# Altium schematic sheet Radioino.SchDoc(Radioino)
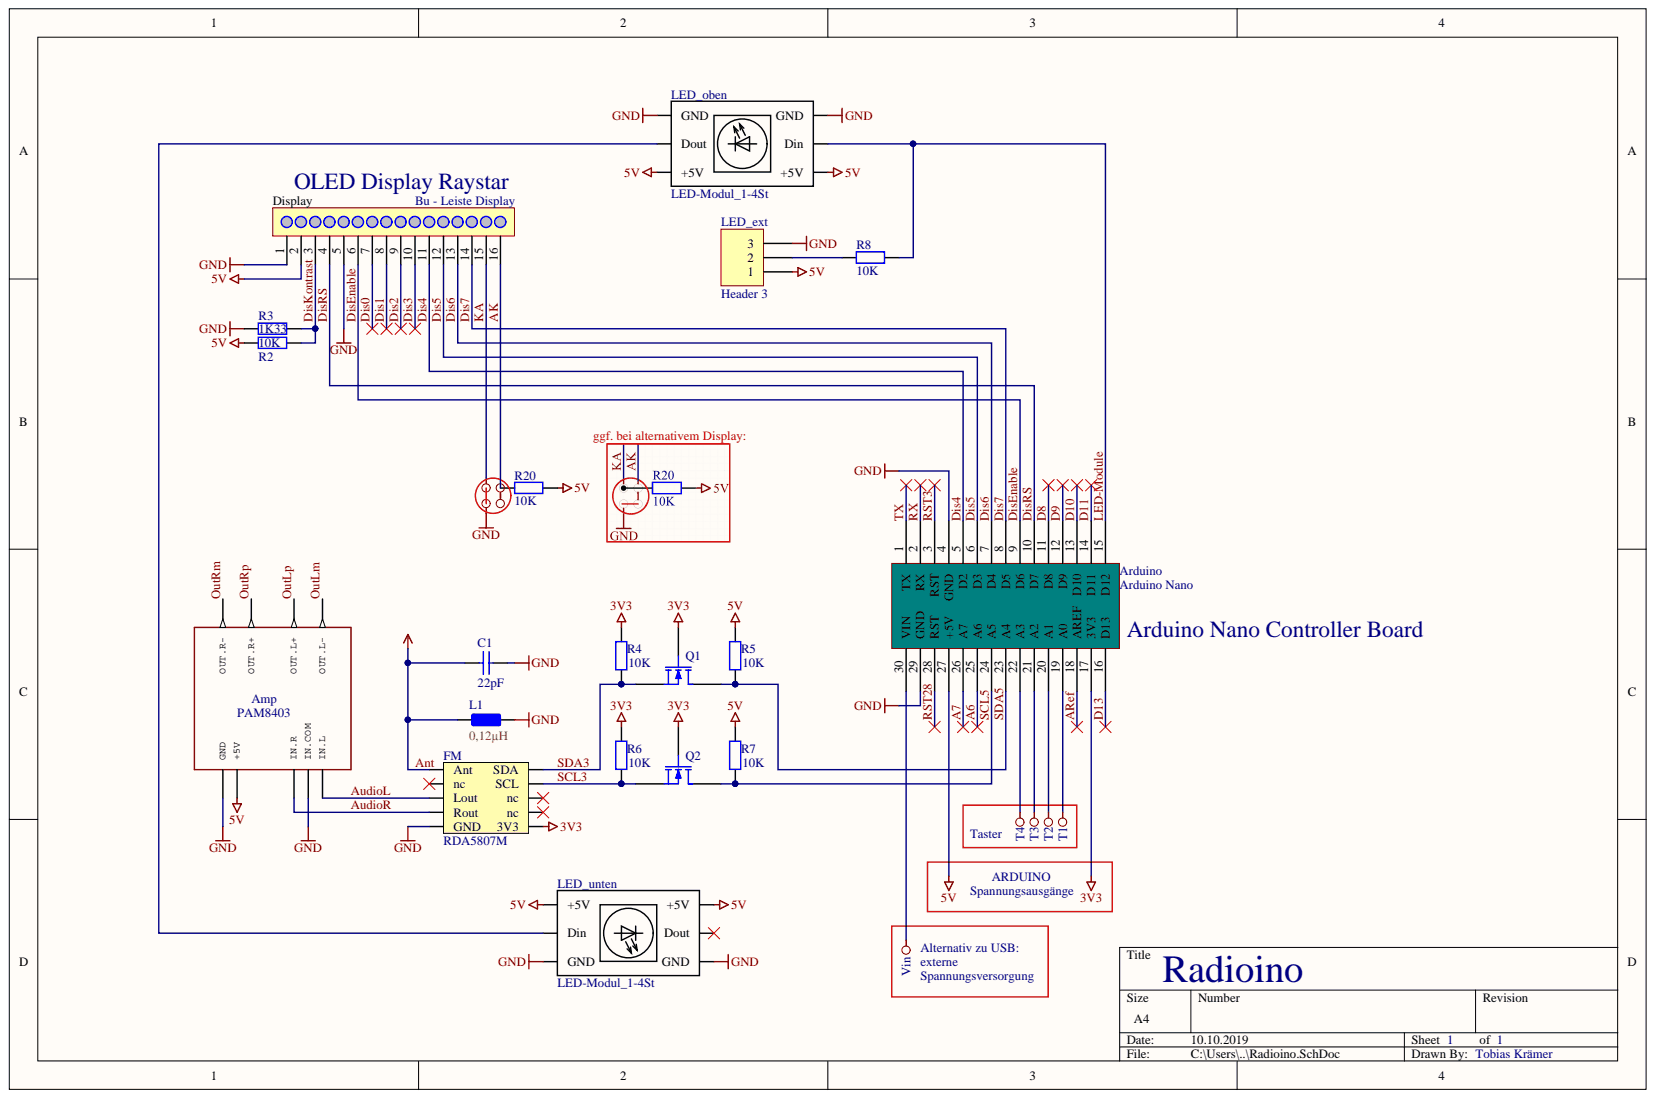
[diagram p0: Radioino.SchDoc]

## Parts
Amp  Item: PAM8403  [AMPLIFIER-PAM8403]  (hidden comment: Stereo Class-D 3W Audio Amplifier based on PAM8403 chip    More details available here: http://artofcircuits.com/product/pam8403-5v-two-channel-stereo-mini-class-d-3w3w-audio-amplifier   Click here to find device on ebay.com)
Arduino  Arduino Nano  [ArduinoNano]
C1  value 22pF  [Kerko]  (hidden comment: Cap)
Display  Bu - Leiste Display  [2908]
FM  RDA5807M  [RDA5807M]
L1  0,12µH  [SpuleAx]
LED_ext  Header 3  [HDR1X3]
LED_oben  LED-Modul_1-4St  [LED-Modul_1-4St]
LED_unten  LED-Modul_1-4St  [LED-Modul_1-4St]
Q1  MOSFET-N  [E3]  (value not drawn)
Q2  MOSFET-N  [E3]  (value not drawn)
R2  value 10K  [AXIAL-0.4]  (hidden comment: Res2)
R3  value 1K33  [AXIAL-0.4]  (hidden comment: Res2)
R4  value 10K  [AXIAL-0.4]  (hidden comment: Res2)
R5  value 10K  [AXIAL-0.4]  (hidden comment: Res2)
R6  value 10K  [AXIAL-0.4]  (hidden comment: Res2)
R7  value 10K  [AXIAL-0.4]  (hidden comment: Res2)
R8  value 10K  [AXIAL-0.4]  (hidden comment: Res2)
R20  value 10K  [AXIAL-0.4]  (hidden comment: Res2)

## Nets
3V3: Arduino.17(3V3) FM.10(3V3) Q1.2 Q2.2 R4.2 R6.2
5V: Amp.+5V Arduino.27(+5V) Display.2 LED_ext.1 LED_oben.3(+5V) LED_oben.4(+5V) LED_unten.3(+5V) LED_unten.4(+5V) R2.2 R5.2 R7.2 R20.2
GND: Amp.GND Amp.IN.COM Arduino.4(GND) Arduino.29(GND) C1.1 Display.1 Display.5 FM.1(GND) L1.1 LED_ext.3 LED_oben.1(GND) LED_oben.6(GND) LED_unten.1(GND) LED_unten.6(GND) R3.1
T1: Arduino.19(A0)
T2: Arduino.20(A1)
T3: Arduino.21(A2)
T4: Arduino.22(A3)
Vin: Arduino.30(VIN)
A6: Arduino.25(A6)
A7: Arduino.26(A7)
AK: Display.16 R20.1
Ant: C1.2 FM.5(Ant) L1.2
ARef: Arduino.18(AREF)
AudioL: Amp.IN.L FM.3(Lout)
AudioR: Amp.IN.R FM.2(Rout)
D8: Arduino.11(D8)
D9: Arduino.12(D9)
D10: Arduino.13(D10)
D11: Arduino.14(D11)
D13: Arduino.16(D13)
Dis0: Display.7
Dis1: Display.8
Dis2: Display.9
Dis3: Display.10
Dis4: Arduino.5(D2) Display.11
Dis5: Arduino.6(D3) Display.12
Dis6: Arduino.7(D4) Display.13
Dis7: Arduino.8(D5) Display.14
DisEnable: Arduino.9(D6) Display.6
DisKontrast: Display.3 R2.1 R3.2
DisRS: Arduino.10(D7) Display.4
KA: Display.15
LED-Module: Arduino.15(D12) LED_oben.2(Din) R8.2
OutLm: Amp.OUT.L-
OutLp: Amp.OUT.L+
OutRm: Amp.OUT.R-
OutRp: Amp.OUT.R+
RST3: Arduino.3(RST)
RST28: Arduino.28(RST)
RX: Arduino.2(RX)
SCL3: FM.7(SCL) Q2.3 R6.1
SCL5: Arduino.24(A5) Q2.1 R7.1
SDA3: FM.6(SDA) Q1.3 R4.1
SDA5: Arduino.23(A4) Q1.1 R5.1
TX: Arduino.1(TX)
NetLED_ext_2: LED_ext.2 R8.1
NetLED_oben_5: LED_oben.5(Dout) LED_unten.2(Din)

Not connected: FM 4(nc) 8(nc) 9(nc); LED_unten 5(Dout)

## Library data of the parts
Amp = AMPLIFIER-PAM8403: Library Name: AMPLIFIER-PAM8403.SCHLIB; Component Kind: Standard
Arduino = ArduinoNano: Library Name: ArduinoNano.SchLib; Component Kind: Standard
C1 = Cap: Capacitor; Library Name: Miscellaneous Devices.IntLib; Component Kind: Standard
Display = 2908: Buchsenl. 1reih.ger.16pol.Au RM2,54; Bearbeiter: Udo Schmitt; Value: Bu - Leiste Display; LNR: 2908; Datum: 05.10.2009; Component Kind: Standard; Library Name: Feig-Schematic.SCHLIB
L1 = 27231: Value: 0,12µH; Component Kind: Standard; Library Name: Feig-Schematic.SCHLIB
LED_ext = Header 3: Header, 3-Pin; Signal Integrity: Connector; Library Name: Miscellaneous Connectors.IntLib; LatestRevisionNote: Re-released for DXP Platform.; LatestRevisionDate: 17-Jul-2002; Component Kind: Standard; Publisher: Altium Limited
Q1 Q2 = MOSFET-N: N-Channel MOSFET; Simulation: NMOS; Published: 8-Jun-2000; PackageVersion: 1995/1996; PackageReference: E3; PackageDescription: TO, Flat Index; 3 In-Line, Axial Leads; Body Dia. 4.6mm; Lead Dia. 0.5 mm (max); Library Name: Miscellaneous Devices.IntLib; LatestRevisionNote: Re-released for DXP Platform.; LatestRevisionDate: 17-Jul-2002; Component Kind: Standard; Publisher: Altium Limited
R2-R8 R20 = Res2: Resistor; Simulation: RESISTOR; Signal Integrity: Res; Published: 8-Jun-2000; PackageReference: AXIAL-0.4; PackageDescription: Axial Device, Thru-Hole; 2 Leads; 0.4 in Pin Spacing; Library Name: Miscellaneous Devices.IntLib; LatestRevisionNote: Re-released for DXP Platform.; LatestRevisionDate: 17-Jul-2002; Component Kind: Standard; Publisher: Altium Limited
FM = RDA5807M: Library Name: RDA5807M.SchLib; Component Kind: Standard
LED_oben LED_unten = LED-Modul_1-4St: Library Name: LED-Modul_1-4St.SchLib; Component Kind: Standard
